# Altium schematic sheet percepcao_externa_official.SchDoc
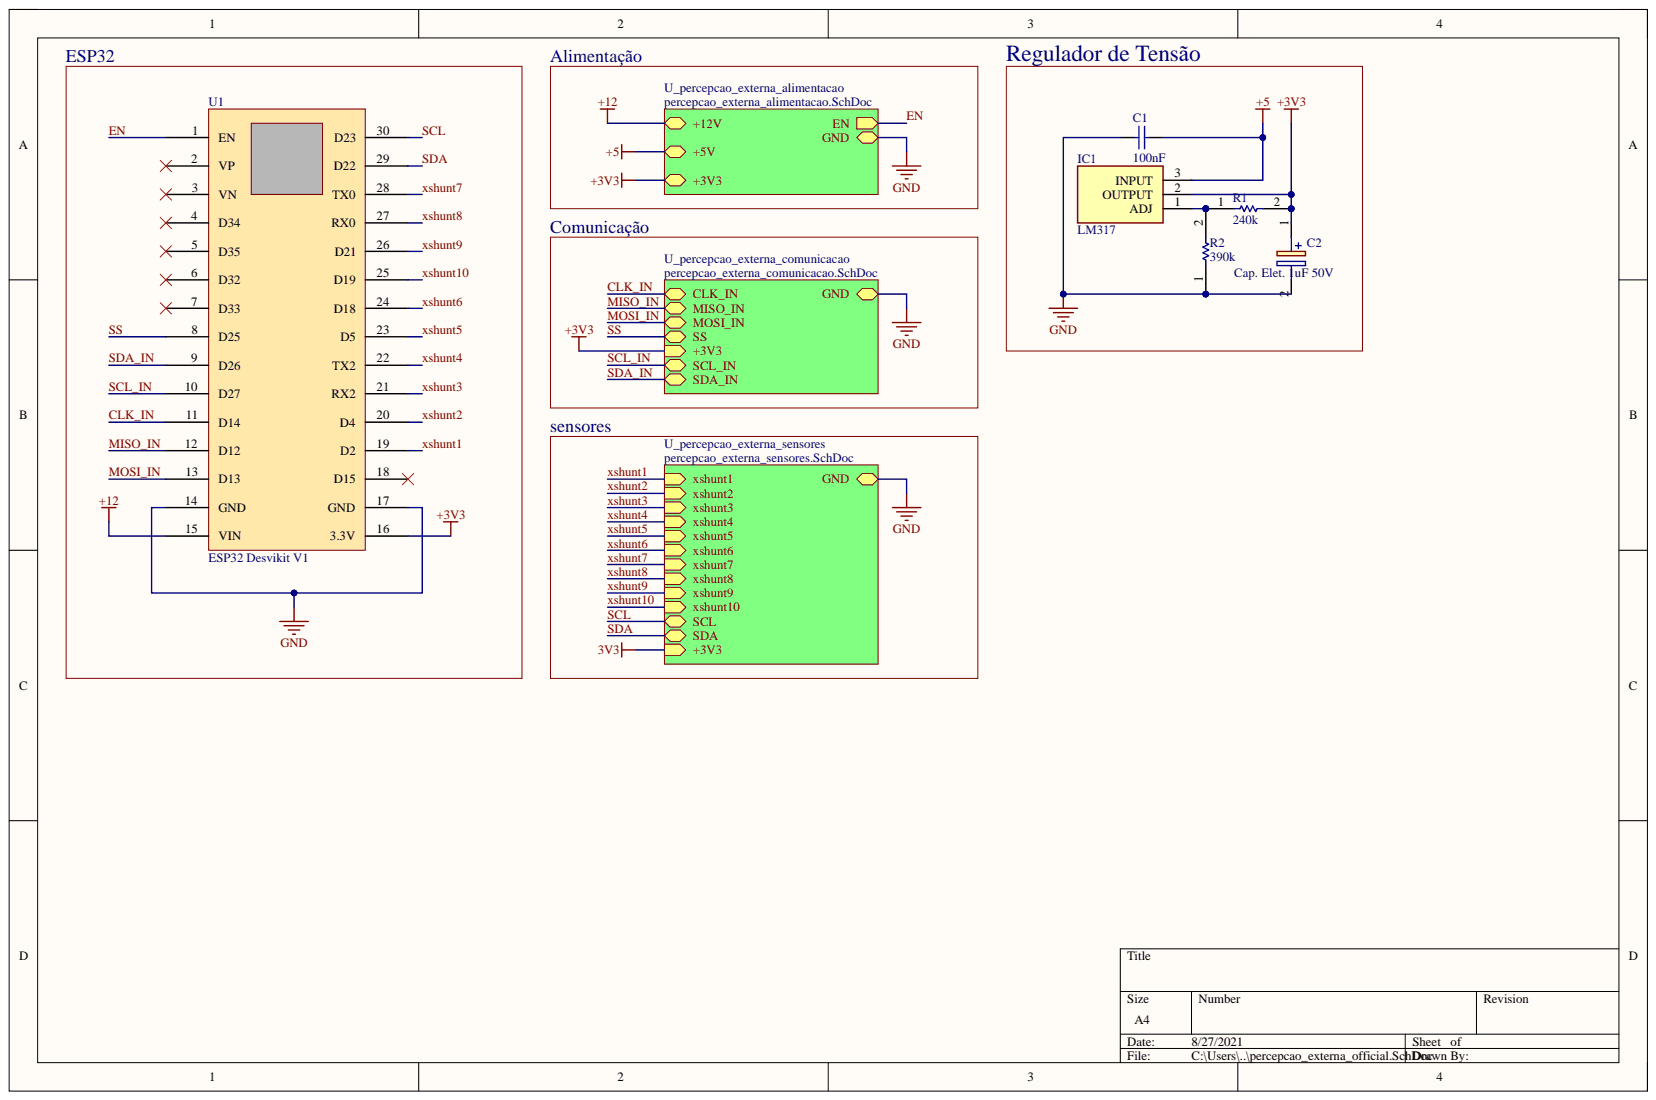
[diagram p0: percepcao_externa_official.SchDoc]

## Parts
C1  100nF  [CAP CER DISK 10uF 50V]
C2  Cap. Elet. 1uF 50V  [Cap. Elet. 470uF 16V]
IC1  LM317  [TO254P467X1016X1971-3P]
R1  240k  [RESC3216X60N]
R2  390k  [RESC3216X60N]
U1  value ESP32 Desvikit V1  [ESP32_Desvikit_v1]  (hidden comment: microcontrolador)

## Nets
3V3: C2.1 IC1.2 R1.2 U1.16(3.3V)
+5: C1.2 IC1.3
+12: U1.15(VIN)
GND: C1.1 C2.2 R2.1 U1.14(GND) U1.17(GND)
CLK_IN: U1.11(D14)
EN: U1.1(EN)
MISO_IN: U1.12(D12)
MOSI_IN: U1.13(D13)
SCL: U1.30(D23)
SCL_IN: U1.10(D27)
SDA: U1.29(D22)
SDA_IN: U1.9(D26)
SS: U1.8(D25)
xshunt1: U1.19(D2)
xshunt2: U1.20(D4)
xshunt3: U1.21(RX2)
xshunt4: U1.22(TX2)
xshunt5: U1.23(D5)
xshunt6: U1.24(D18)
xshunt7: U1.28(TX0)
xshunt8: U1.27(RX0)
xshunt9: U1.26(D21)
xshunt10: U1.25(D19)
NetIC1_1: IC1.1 R1.1 R2.2
NetU1_2: U1.2(VP)
NetU1_3: U1.3(VN)
NetU1_4: U1.4(D34)
NetU1_5: U1.5(D35)
NetU1_6: U1.6(D32)
NetU1_7: U1.7(D33)
NetU1_18: U1.18(D15)

## Library data of the parts
C1 = CAP CER DISK 10uF 50V_1: CAP CER DISK 10uF 50V; Manufacturer Part Number: IMP14925; Capacitance: 0.1uF; Capacitance Tolerance: +80%, -20%; Capacitor Terminals: Radial Leaded; Dielectric Characteristic: Y5; Product Range: MCFY Series; SVHC: No SVHC (15-Jun-2015); Voltage Rating: 50; Capacitance Tolerance ±: +80%, -20%; Component Kind: Standard; ComponentLink1Description: http://loja.multcomercial.com.br/capacitor-ceramico-disco-100kpf-50v-104-100k-100nf-0-1uf-cod-1351.html; ComponentLink1URL: http://loja.multcomercial.com.br/capacitor-ceramico-disco-100kpf-50v-104-100k-100nf-0-1uf-cod-1351.html; Lead Spacing: 5; Manufacturer: -; Operating Temperature Max: 85; Operating Temperature Min: -25; Operating Temperature Range: -25°C to +85°C<!--FANDB--><div class="features-and-benefits-container"><div class="features-and-benefits-description"><span class="features-benefits-value">The MCFY series from Multicomp are radial leaded ceramic disc capacitors. The capacitance values ranges from 6800pF to 0.22?F.</span></div><div class="features-and-benefits-list"><ul class="features-and-benefits-list-list"><li class="features-and-benefits-list-item">Large capacitance in small size</li><li class="features-and-benefits-list-item">Rated voltage of 50V</li><li class="features-and-benefits-list-item">80%/-20% tolerance</li><li class="features-and-benefits-list-item">Operating temperature range -25°C to +85; Packaging: Each; Pricing 1: 1=0.10 (BRL); RoHS: YES; Stock 1: 115809; Supplier 1: Mult Comercial; Supplier Part Number 1: Capacitor Cerâmico Disco 100Kpf/50V (104/100K/100nF/0.1uF) cód. 1351; Library Name: RoboHospitalar.SchLib
C2 = Cap. Elet. 470 uF 16V: Aluminum Organic Polymer Capacitors 16volts 470uF ESR 10mohm; Manufacturer_Name: Panasonic; Manufacturer_Part_Number: 16SEPC470M; Arrow Part Number: 16SEPC470M; Arrow Price/Stock: https://www.arrow.com/en/products/16sepc470m/panasonic; Component Kind: Standard; Datasheet Link: http://industrial.panasonic.com/www-cgi/jvcr13pz.cgi?E+CI+4+AAB8010+16SEPC470M+8+WW; Height: 13mm; Library Name: esp32.SchLib; Mouser Part Number: 667-16SEPC470M; Mouser Price/Stock: https://www.mouser.co.uk/ProductDetail/Panasonic/16SEPC470M?qs=OE1iw1LrrPEdZuWyF2l%252B5w%3D%3D
IC1 = LM317: Integrated Circuit; Manufacturer_Name: Changjiang Electronics Tech (CJ); Component Kind: Standard; Datasheet Link: https://datasheet.lcsc.com/szlcsc/Changjiang-Electronics-Tech-CJ-LM317_C22147.pdf; Height: 4.67mm; Manufacturer_Part_Number: LM317; Library Name: RoboHospitalar.SchLib
R1 R2 = RES 1206: RES 1206 5%; Manufacturer_Name: Vishay; Manufacturer_Part_Number: CRCW12064K70FKEA; Arrow Part Number: CRCW12064K70FKEA; Arrow Price/Stock: https://www.arrow.com/en/products/crcw12064k70fkea/vishay; Component Kind: Standard; Datasheet Link: http://docs-emea.rs-online.com/webdocs/13ec/0900766b813ecc08.pdf; Height: 0.6mm; Mouser Part Number: 71-CRCW1206-4.7K-E3; Mouser Price/Stock: https://www.mouser.co.uk/ProductDetail/Vishay-Dale/CRCW12064K70FKEA?qs=1vm4qhDHCvlKJmatsKzyFQ%3D%3D; Library Name: RoboHospitalar.SchLib
U1 = ESP32 Desvikit v1: microcontrolador com moculo bluethoth e wifi; Component Kind: Standard; Library Name: Sinalização_Official.SchLib
